# Altium schematic sheet RS485.SchDoc(RS485)
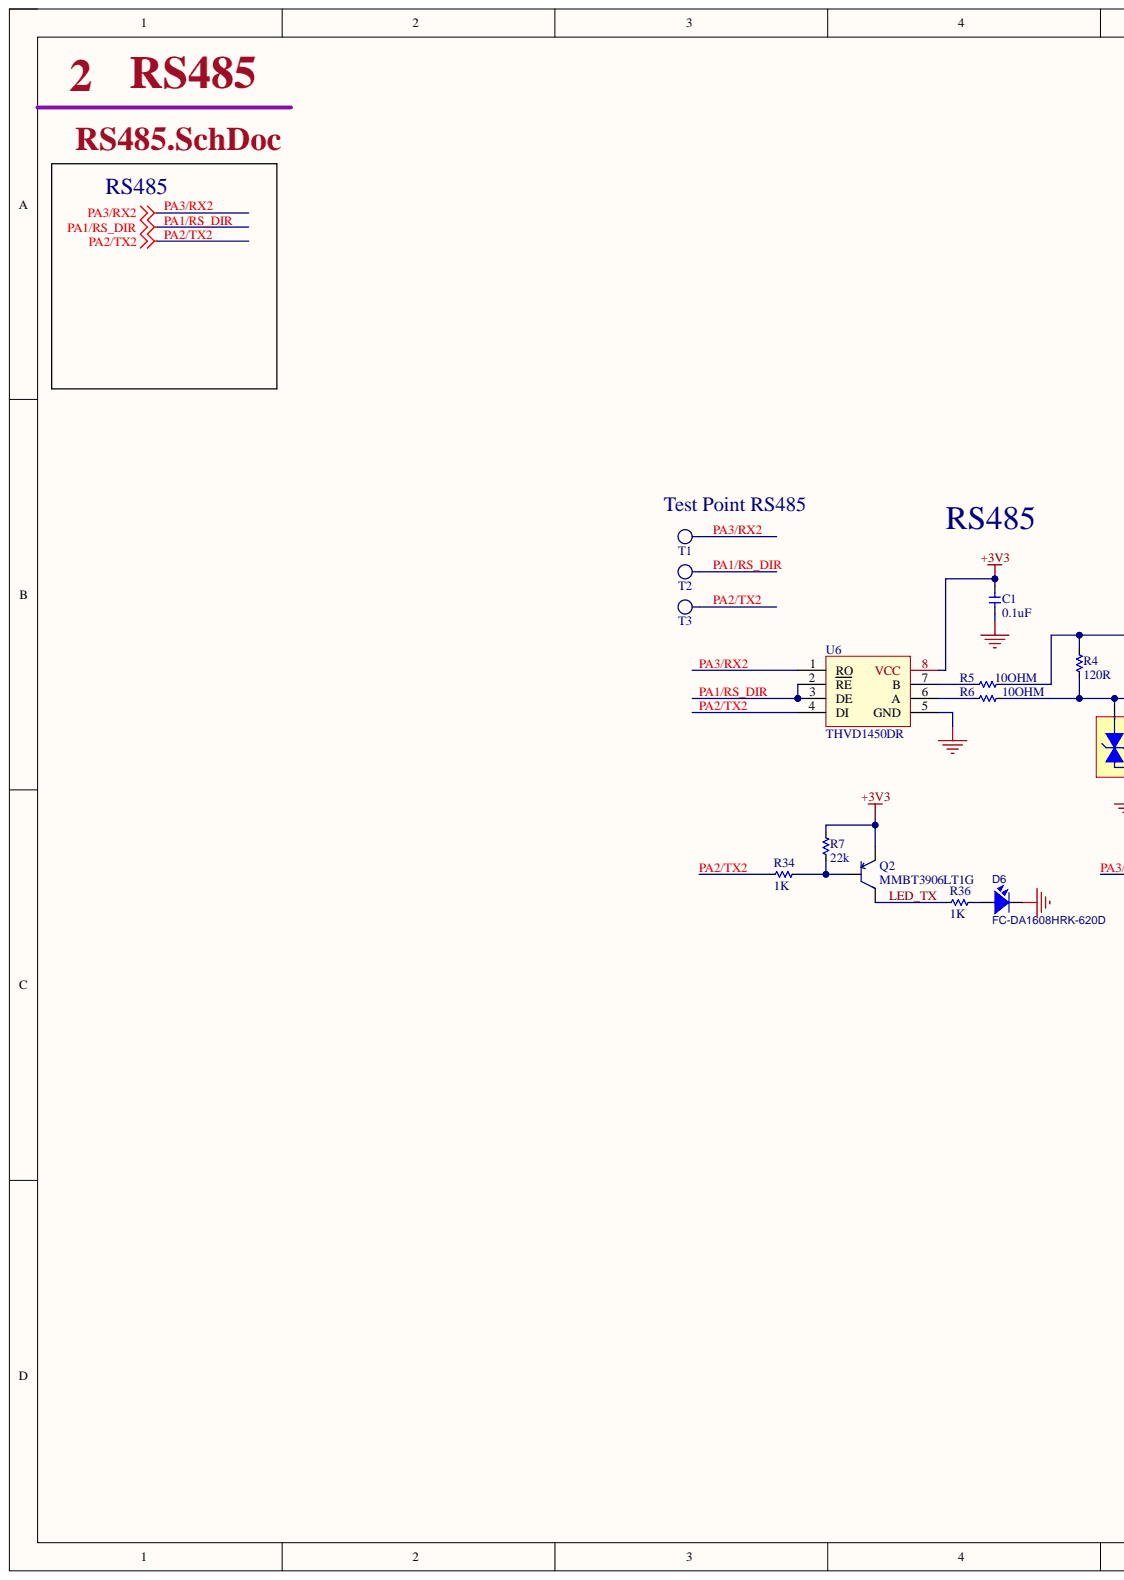
[diagram p0: RS485.SchDoc, left]
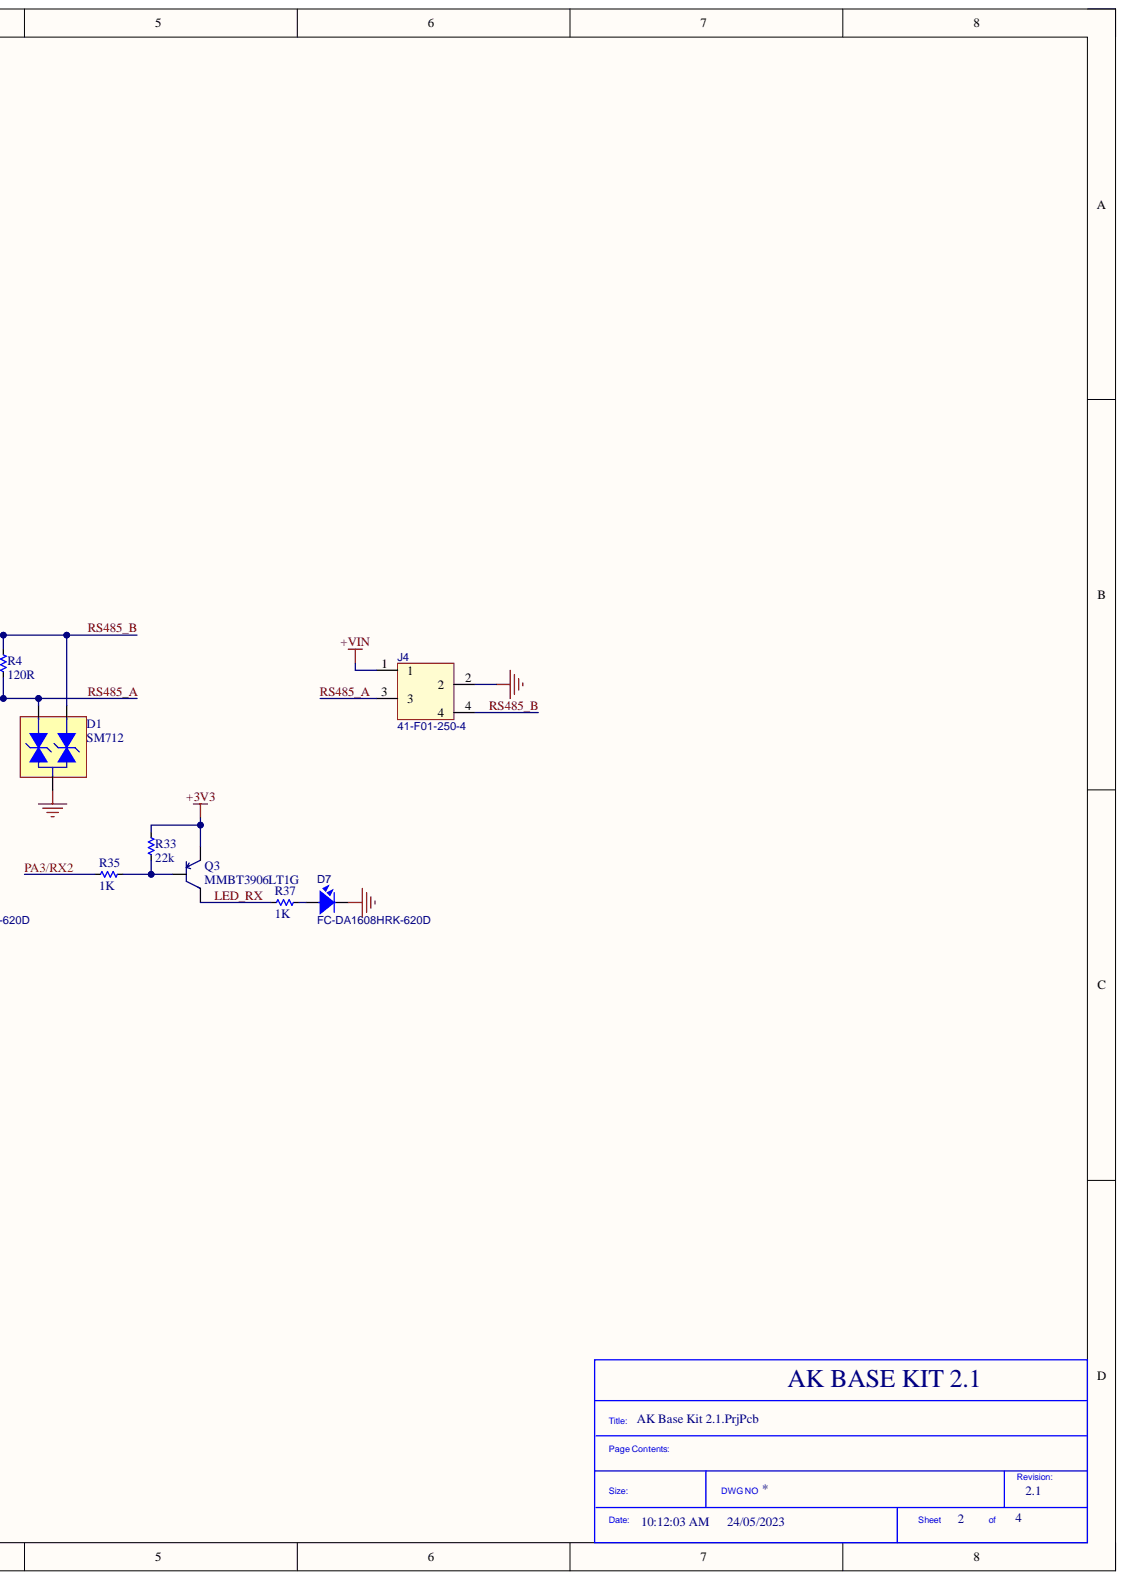
[diagram p1: RS485.SchDoc, right]

## Parts
C1  0.1uF  [C_0603]
D1  SM712  [SOT-23-3_L2.9-W1.6-P1.90-LS2.8-BR]
D6  FC-DA1608HRK-620D  [LED0603-RD_RED]
D7  FC-DA1608HRK-620D  [LED0603-RD_RED]
J4  41-F01-250-4  [CONN-TH_41-F01-250-4]
Q2  MMBT3906LT1G  [SOT-23-3_L2.9-W1.6-P1.90-LS2.8-BR]
Q3  MMBT3906LT1G  [SOT-23-3_L2.9-W1.6-P1.90-LS2.8-BR]
R4  120R  [R_0603]
R5  10OHM  [R_0805]
R6  10OHM  [R_0805]
R7  22k  [R_0402]
R33  22k  [R_0402]
R34  1K  [R_0402]
R35  1K  [R_0402]
R36  1K  [R_0402]
R37  1K  [R_0402]
T1  TEST-POINT'4545'  [TP-D45MIL]  (value not drawn)  (no BOM)
T2  TEST-POINT'4545'  [TP-D45MIL]  (value not drawn)  (no BOM)
T3  TEST-POINT'4545'  [TP-D45MIL]  (value not drawn)  (no BOM)
U6  THVD1450DR  [SOIC-8_3.9x4.9x1.27P]

## Nets
+3V3: C1.2 Q2.2 Q3.2 R7.1 R33.1 U6.8(VCC)
+VIN: J4.1
GND: C1.1 D1.3 D6.1 D7.1 J4.2 U6.5(GND)
LED_RX: Q3.3 R37.2
LED_TX: Q2.3 R36.2
PA1/RS_DIR: T2.T U6.2(RE) U6.3(DE)
PA2/TX2: R34.2 T3.T U6.4(DI)
PA3/RX2: R35.2 T1.T U6.1(RO)
RS485_A: D1.2 J4.3 R4.1 R6.1
RS485_B: D1.1 J4.4 R4.2 R5.1
NetD6_2: D6.2 R36.1
NetD7_2: D7.2 R37.1
NetQ2_1: Q2.1 R7.2 R34.1
NetQ3_1: Q3.1 R33.2 R35.1
NetR5_2: R5.2 U6.7(B)
NetR6_2: R6.2 U6.6(A)

## Library data of the parts
C1 = CC0603KRX7R9BB104: 100NF 50V 0603 ROHS; Manufacturer: YAGEO; Assembly Type: SMT Assembly; Component Kind: Standard; ComponentLink1Description: Digikey Link; ComponentLink1URL: https://www.digikey.com/en/products/detail/yageo/CC0603KRX7R9BB104/2103082?s=N4IgTCBcDaIMwEYEFoFwCzuWZA5AIiALoC%2BQA; ComponentLink2Description: LCSC Link; ComponentLink2URL: https://jlcpcb.com/parts/componentSearch?searchTxt=10k%200603; LCSC Part Number: C14663; Library Name: Dev Board STM OLED 2.0.SCHLIB; Manufacturer Part Number: CC0603KRX7R9BB104; Package: 0603
D1 = SM712-TP: TVS DIODE 12V 21V SOT23; Manufacturer: MDD（Microdiode Electronics）; Assembly Type: SMT Assembly; Component Kind: Standard; ComponentLink1Description: http://www.mccsemi.com/up_pdf/SM712(SOT-23).pdf?src-supplier=Digi-Key; ComponentLink1URL: http://www.mccsemi.com/up_pdf/SM712(SOT-23).pdf?src-supplier=Digi-Key; ComponentLink2Description: http://www.mccsemi.com/up_pdf/SM712(SOT-23).pdf?src-supplier=Future+Electronics; ComponentLink2URL: http://www.mccsemi.com/up_pdf/SM712(SOT-23).pdf?src-supplier=Future+Electronics; ComponentLink3Description: http://61.222.192.61/mccsemi/up_pdf/SM712(SOT-23).pdf?src-supplier=Mouser; ComponentLink3URL: http://61.222.192.61/mccsemi/up_pdf/SM712(SOT-23).pdf?src-supplier=Mouser; LCSC Part Number: C502564; Library Name: Dev Board STM OLED 2.0.SCHLIB; Manufacturer Part Number: SM712; Package: SOT-23-3_L2.9-W1.6-P1.90-LS2.8-BR
D6 D7 = FC-DA1608HRK-620D: LED Red 0603 Light Emitting Diodes (LED) ROHS; Manufacturer: NATIONSTAR; Assembly Type: SMT Assembly; Component Kind: Standard; LCSC Part Number: C84263; Library Name: Dev Board STM OLED 2.0.SCHLIB; Manufacturer Part Number: FC-DA1608HRK-620D; Package: LED_0603
J4 = Terminal 41-F01-250-4: Terminal Block  4 0.138"（3.50mm） 24~16 0.5~0.75 P=3.5mm Spring Clamp System Terminal Block RoHS; Manufacturer: HIWA; Assembly Type: Hand Soldering; Component Kind: Standard; LCSC Part Number: C173392; Library Name: Dev Board STM OLED 2.0.SCHLIB; Manufacturer Part Number: 41-F01-250-4; Package: Through hole
Q2 Q3 = MMBT3906LT1G: MMBT3906 TRANSISTORS (NPN/PNP) PNP 200MA 40V SOT-23(SOT-23-3) ROHS; Manufacturer: ON Semicon; Assembly Type: SMT Assembly; Component Kind: Standard; LCSC Part Number: C53444; Library Name: Dev Board STM OLED 2.0.SchLib; Manufacturer Part Number: MMBT3906LT1G; Package: SOT-23-3
R4 = 0603WAF1200T5E: 120 OHMS ±1% 1/10W 0603 ROHS; Manufacturer: UNI-ROYAL(Uniroyal Elec); Assembly Type: SMT Assembly; Component Kind: Standard; LCSC Part Number: C22787; Library Name: USB to Multi RS485 1.0.SCHLIB; Manufacturer Part Number: 0603WAF1200T5E; Package: 0603
R5 R6 = 0805W8F100JT5E: 10OHMS ±1% 1/8W 0805 ROHS; Manufacturer: Uniroyal Elec; Assembly Type: SMT Assembly; Component Kind: Standard; LCSC Part Number: C17415; Library Name: Dev Board STM OLED 2.0.SCHLIB; Manufacturer Part Number: 0805W8F100JT5E; Package: 0805
R7 R33 = 0402WGF2202TCE: 22k 0402 62.5mW Thick Film Resistors 50V ±100ppm/℃ ±1% -55℃~+155℃ 22kΩ; Manufacturer: Uniroyal Elec; Assembly Type: SMT Assembly; Component Kind: Standard; LCSC Part Number: C25768; Library Name: Dev Board STM OLED 2.0.SchLib; Manufacturer Part Number: 0402WGF2202TCE; Package: 0402
R34-R37 = 0402WGF1001TCE: 1kΩ 0402 62.5mW Thick Film Resistors 50V ±100ppm/℃ ±1% -55℃~+155℃; Manufacturer: Uniroyal Elec; Assembly Type: SMT Assembly; Component Kind: Standard; LCSC Part Number: C11702; Library Name: Dev Board STM OLED 2.0.SchLib; Manufacturer Part Number: 0402WGF1001TCE; Package: 0402
T1-T3 = TEST-POINT'4545': Manufacturer: No; Description: TEST-POINT'4545'; LCSC Part Number: No; Library Name: Dev Board STM OLED 2.0.SchLib; Manufacturer Part Number: No; Package: No
U6 = THVD1450DR: THVD1450DR transceiver 3V~5.5V 50Mbps 1/1 SOIC-8_150mil RS-485/RS-422 ICs ROHS; Manufacturer: Texas Instruments; Assembly Type: SMT Assembly; Component Kind: Standard; ComponentLink1Description: http://www.ti.com/lit/ds/symlink/sn65hvd1785.pdf?src-supplier=Digi-Key; ComponentLink1URL: http://www.ti.com/lit/ds/symlink/sn65hvd1785.pdf?src-supplier=Digi-Key; ComponentLink2Description: http://datasheet.iiic.cc/texas_instruments/SN65HVD1785DR.pdf?src-supplier=IIIC; ComponentLink2URL: http://datasheet.iiic.cc/texas_instruments/SN65HVD1785DR.pdf?src-supplier=IIIC; LCSC Part Number: C2671361; Library Name: Dev Board STM OLED 2.0.SchLib; Manufacturer Part Number: THVD1450DR; Package: SOIC-8_3.9x4.9x1.27P
